# Altium schematic sheet USART.SchDoc(USART)
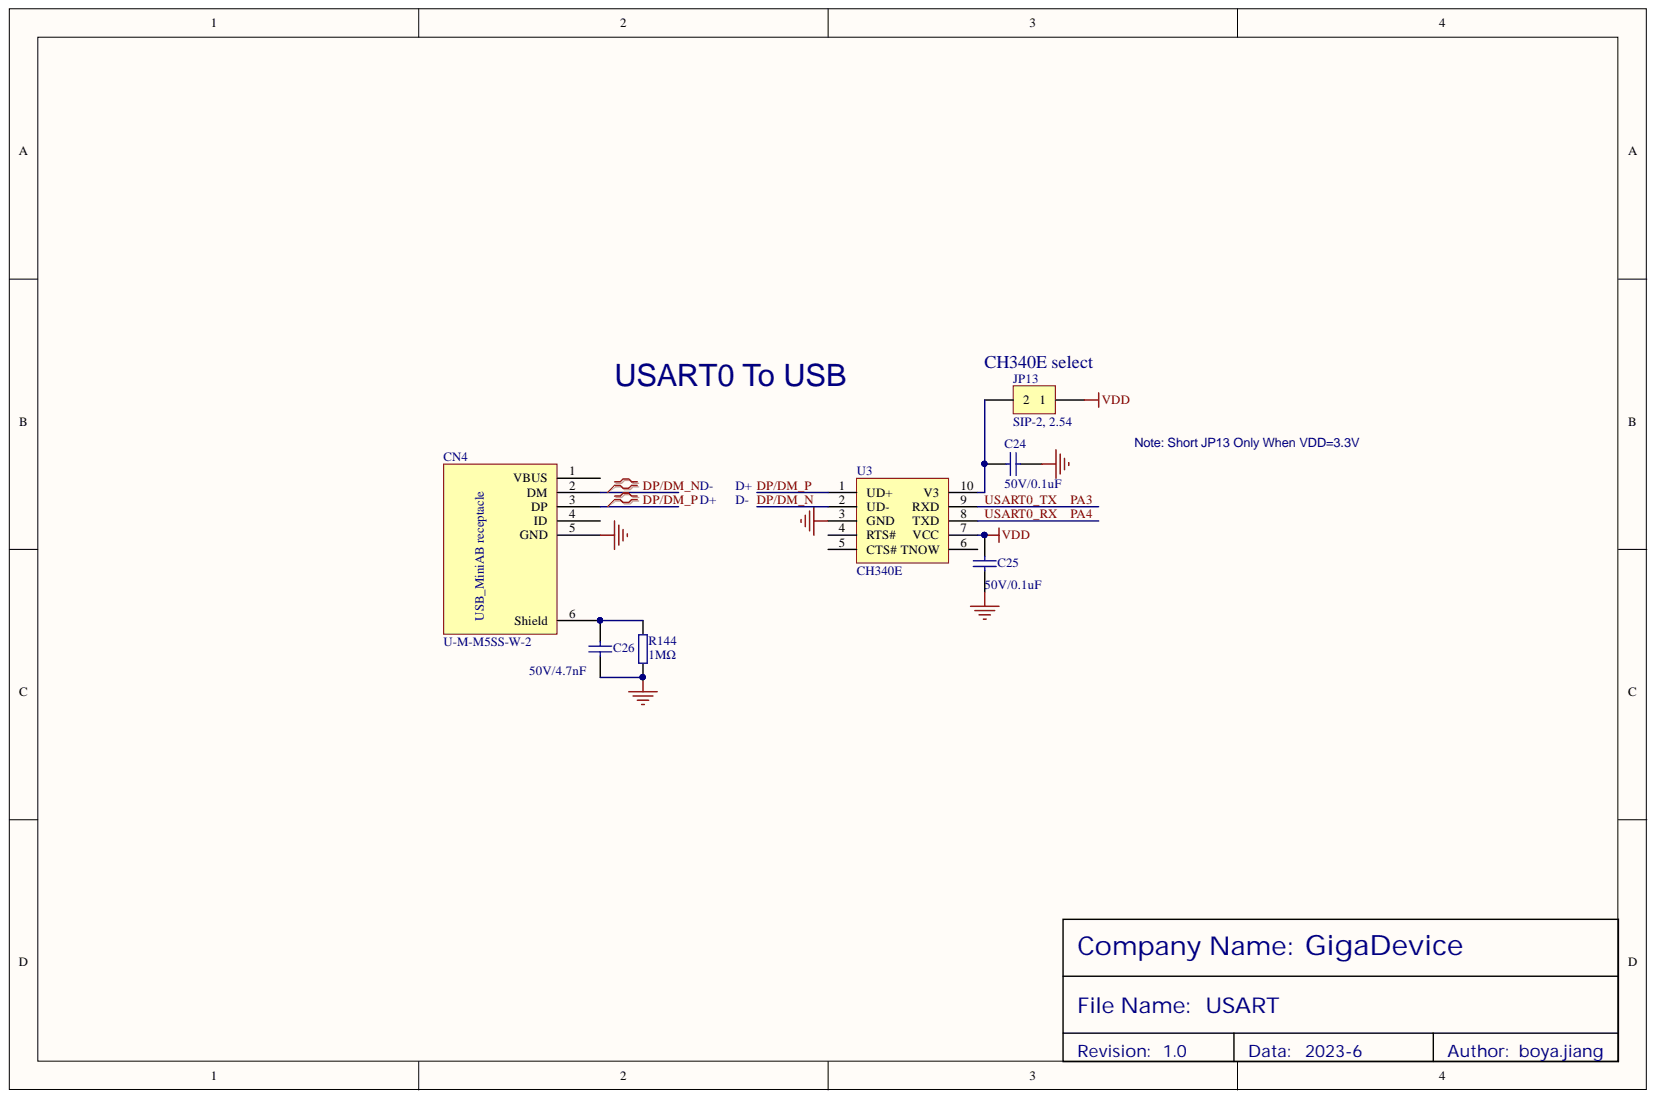
[diagram p0: USART.SchDoc]

## Parts
C24  50V/0.1uF  [0603-C]
C25  50V/0.1uF  [0603-C]
C26  50V/4.7nF  [0603-C]
CN4  U-M-M5SS-W-2  [USB_MINI]
JP13  SIP-2, 2.54  [SIP-2]
R144  1MΩ  [0603-R]
U3  CH340E  [MSOP-10]

## Nets
GND: C24.1 C25.1 C26.1 CN4.5(GND) R144.1 U3.3(GND)
VDD: C25.2 JP13.1 U3.7(VCC)
DP/DM_N: CN4.2(DM) U3.2(UD-)
DP/DM_P: CN4.3(DP) U3.1(UD+)
PA3: U3.9(RXD)
PA4: U3.8(TXD)
NetC24_2: C24.2 JP13.2 U3.10(V3)
NetC26_2: C26.2 CN4.6(Shield) R144.2

Not connected: CN4 1(VBUS) 4(ID); U3 4(RTS#) 5(CTS#) 6(TNOW)

## Library data of the parts
C24 = 50V/0.01uF: 表贴电容; Component Kind: Standard; Library Name: KLTC-电容.SchLib
C25 = 50V/0.1uF: 表贴电容; Component Kind: Standard; Library Name: KLTC-电容.SchLib
C26 = 50V/4700pF: 表贴电容; Component Kind: Standard; Library Name: KLTC-电容.SchLib
CN4 = Mini_USB: 表贴miniusb座; Component Kind: Standard; Library Name: xufei.SchLib
JP13 = HEADER 1X2: 单排插针; Component Kind: Standard; Library Name: KLTC-按键及接插件.SchLib
R144 = 1MΩ: 表贴电阻; Component Kind: Standard; Library Name: KLTC-电阻.SchLib
U3 = CH340E: 表贴USART芯片; Library Name: Temp.SchLib; Component Kind: Standard
